# Altium schematic sheet Proc.SchDoc
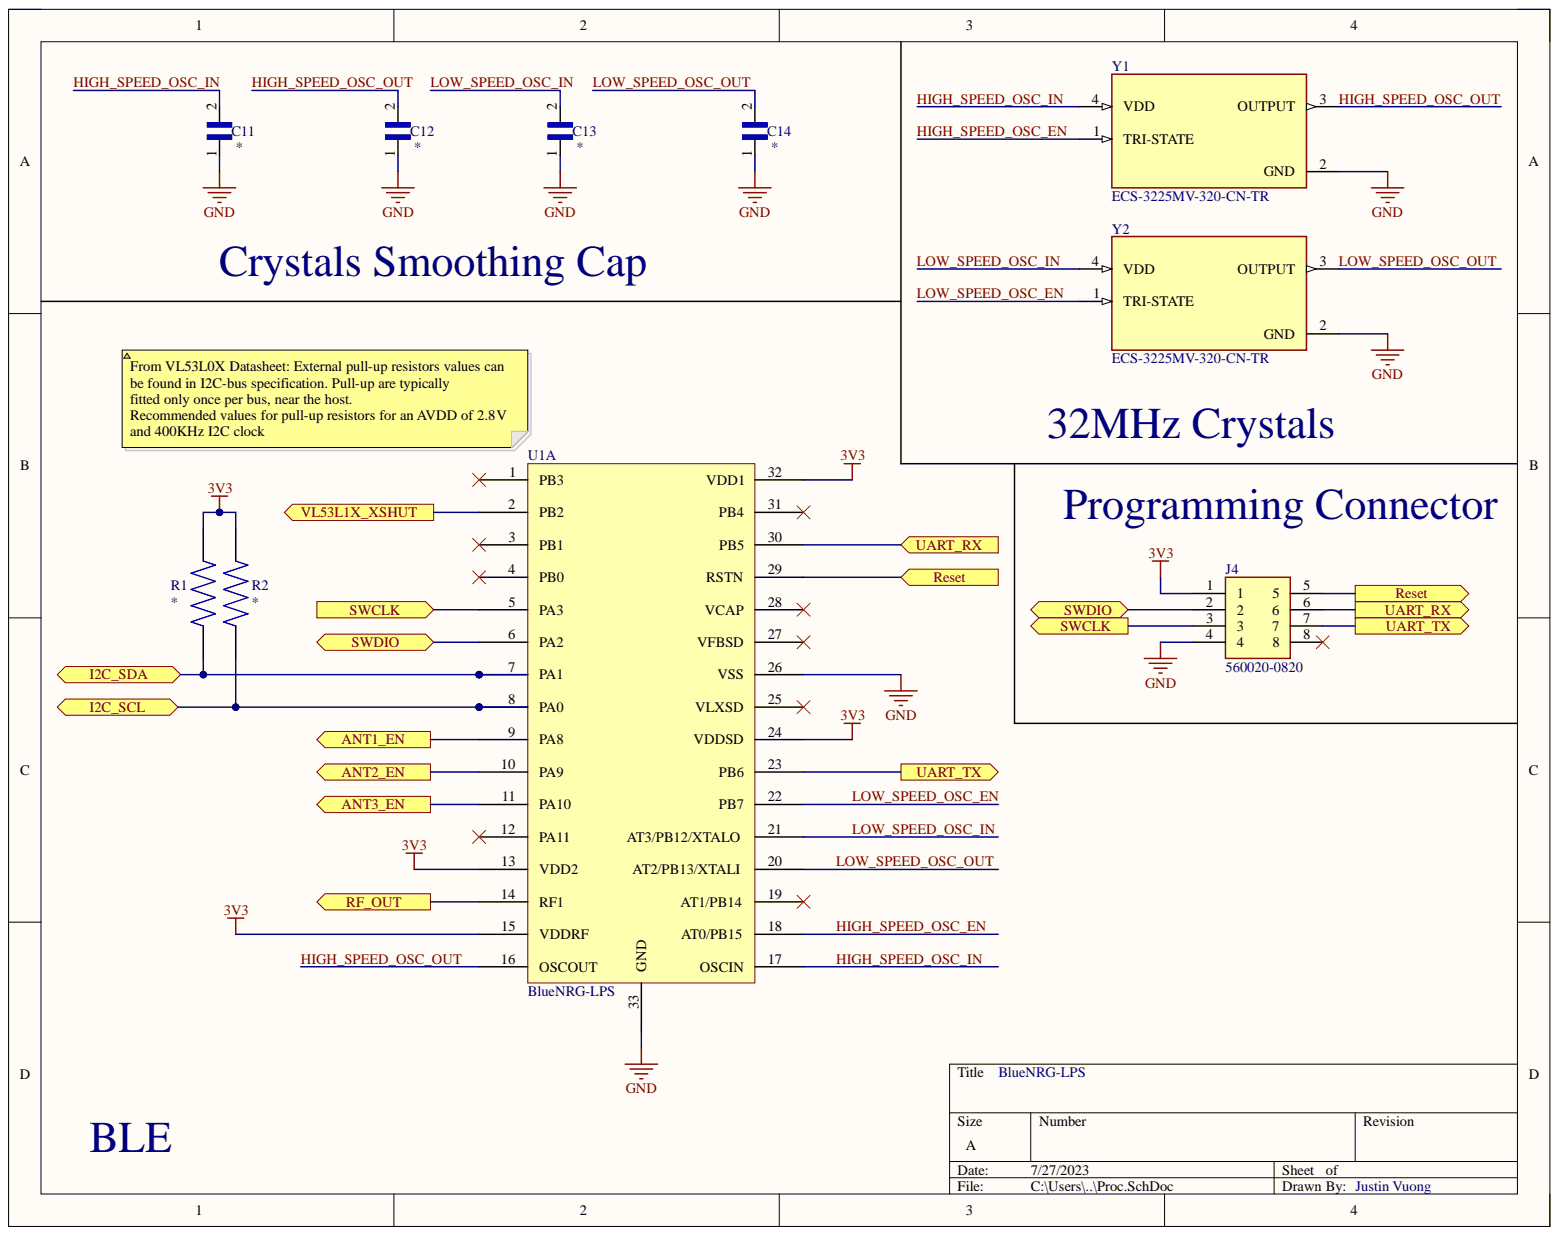
[diagram p0: Proc.SchDoc]

## Parts
C11  04025A8R2CAT2A  [CAPC1005X56N]  (value not drawn)
C12  04025A8R2CAT2A  [CAPC1005X56N]  (value not drawn)
C13  04025A8R2CAT2A  [CAPC1005X56N]  (value not drawn)
C14  04025A8R2CAT2A  [CAPC1005X56N]  (value not drawn)
J4  560020-0820  [560020-0820]
R1  RC0402JR-072KL  [RESC1005X40N]  (value not drawn)
R2  RC0402JR-072KL  [RESC1005X40N]  (value not drawn)
U1  BlueNRG-LPS  [VFQFPN32-5x5mm-M]  (part A)
Y1  ECS-3225MV-320-CN-TR  [OSC_ECS-2333-160-BN-TR]
Y2  ECS-3225MV-320-CN-TR  [OSC_ECS-2333-160-BN-TR]

## Ports
ANT1_EN, ANT2_EN, ANT3_EN, I2C_SCL, I2C_SDA, Reset, RF_OUT, SWCLK, SWDIO, UART_RX, UART_TX, VL53L1X_XSHUT

## Nets
3V3: J4.1 R1.2 R2.1 U1.13(VDD2) U1.15(VDDRF) U1.24(VDDSD) U1.32(VDD1)
GND: C11.1 C12.1 C13.1 C14.1 J4.4 U1.26(VSS) U1.33(GND) Y1.2(GND) Y2.2(GND)
ANT1_EN: U1.9(PA8) ; port ANT1_EN
ANT2_EN: U1.10(PA9) ; port ANT2_EN
ANT3_EN: U1.11(PA10) ; port ANT3_EN
HIGH_SPEED_OSC_EN: U1.18(AT0/PB15) Y1.1(TRI-STATE)
HIGH_SPEED_OSC_IN: C11.2 U1.17(OSCIN) Y1.4(VDD)
HIGH_SPEED_OSC_OUT: C12.2 U1.16(OSCOUT) Y1.3(OUTPUT)
I2C_SCL: R2.2 U1.8(PA0) ; port I2C_SCL
I2C_SDA: R1.1 U1.7(PA1) ; port I2C_SDA
LOW_SPEED_OSC_EN: U1.22(PB7) Y2.1(TRI-STATE)
LOW_SPEED_OSC_IN: C13.2 U1.21(AT3/PB12/XTALO) Y2.4(VDD)
LOW_SPEED_OSC_OUT: C14.2 U1.20(AT2/PB13/XTALI) Y2.3(OUTPUT)
Reset: J4.5 U1.29(RSTN) ; port Reset
RF_OUT: U1.14(RF1) ; port RF_OUT
SWCLK: J4.3 U1.5(PA3) ; port SWCLK
SWDIO: J4.2 U1.6(PA2) ; port SWDIO
UART_RX: J4.6 U1.30(PB5) ; port UART_RX
UART_TX: J4.7 U1.23(PB6) ; port UART_TX
VL53L1X_XSHUT: U1.2(PB2) ; port VL53L1X_XSHUT

Not connected: J4 8; U1 1(PB3) 3(PB1) 4(PB0) 12(PA11) 19(AT1/PB14) 25(VLXSD) 27(VFBSD) 28(VCAP) 31(PB4)

## Library data of the parts
C11-C14 = 04025A8R2CAT2A: 0402 8,2 pF 50 V Â± 0,25 pF TolÃ©rance C0G / NP0 Condensateur cÃ©ramique multicouche SMT; MF: AVX; Component Kind: Standard; Digi-Key_Part_Number: 478-1069-1-ND; Digi-Key_Purchase_URL: https://www.digikey.tn/product-detail/en/avx-corporation/04025A8R2CAT2A/478-1069-1-ND/564101?utm_source=snapeda&utm_medium=aggregator&utm_campaign=symbol; Library Name: 04025A8R2CAT2A.SchLib; MP: 04025A8R2CAT2A; Package: 1005 AVX; SnapEDA_Link: https://www.snapeda.com/parts/04025A8R2CAT2A/AVX/view-part/449449/?ref=snap
J4 = 560020-0820: Connector; Manufacturer_Name: Molex; Component Kind: Standard; Datasheet Link: http://www.molex.com/webdocs/datasheets/pdf/en-us//5600200820_PCB_HEADERS.pdf; Manufacturer_Part_Number: 560020-0820; Mouser Part Number: 538-560020-0820; Mouser Price/Stock: https://www.mouser.co.uk/ProductDetail/Molex/560020-0820?qs=4r2B00tbnb1RvRiPBSc%252Bgw%3D%3D
R1 R2 = RC0402JR-072KL: 2 kOhms Â±5% 0.063W, 1/16W Chip Resistor 0402 (1005 Metric) Moisture Resistant Thick Film; MF: Yageo; Check_prices: https://www.snapeda.com/parts/RC0402JR-072KL/Yageo/view-part/?ref=eda; Component Kind: Standard; DigiKey_Part_Number: 311-2.0KJRTR-ND; Library Name: RC0402JR-072KL.SchLib; MP: RC0402JR-072KL; Package: 1005 Panasonic Electronic Components; Purchase-URL: https://pricing.snapeda.com/search?q=RC0402JR-072KL&ref=eda; SnapEDA_Link: https://www.snapeda.com/parts/RC0402JR-072KL/Yageo/view-part/?ref=snap
U1 = BlueNRG-LPS: IC RF TxRx + MCU Bluetooth Bluetooth v5.3 2.4GHz 32-VFQFN Exposed Pad; Component Kind: Standard; Supplier 1: Mouser; Supplier Part Number 1: 511-BLUENRG-332AT; Library Name: Presgo.SchLib
Y1 Y2 = ECS-3225MV-320-CN-TR: subminiature SMD oscillators.; Availability: Unavailable; Component Kind: Standard; Library Name: ECS-3225MV-320-CN-TR.SchLib; MP: ECS-3225MV-320-CN-TR; Package: None; Price: None
